# Altium schematic sheet AccelerometerSchematic.SchDoc(AccelerometerSchematic)
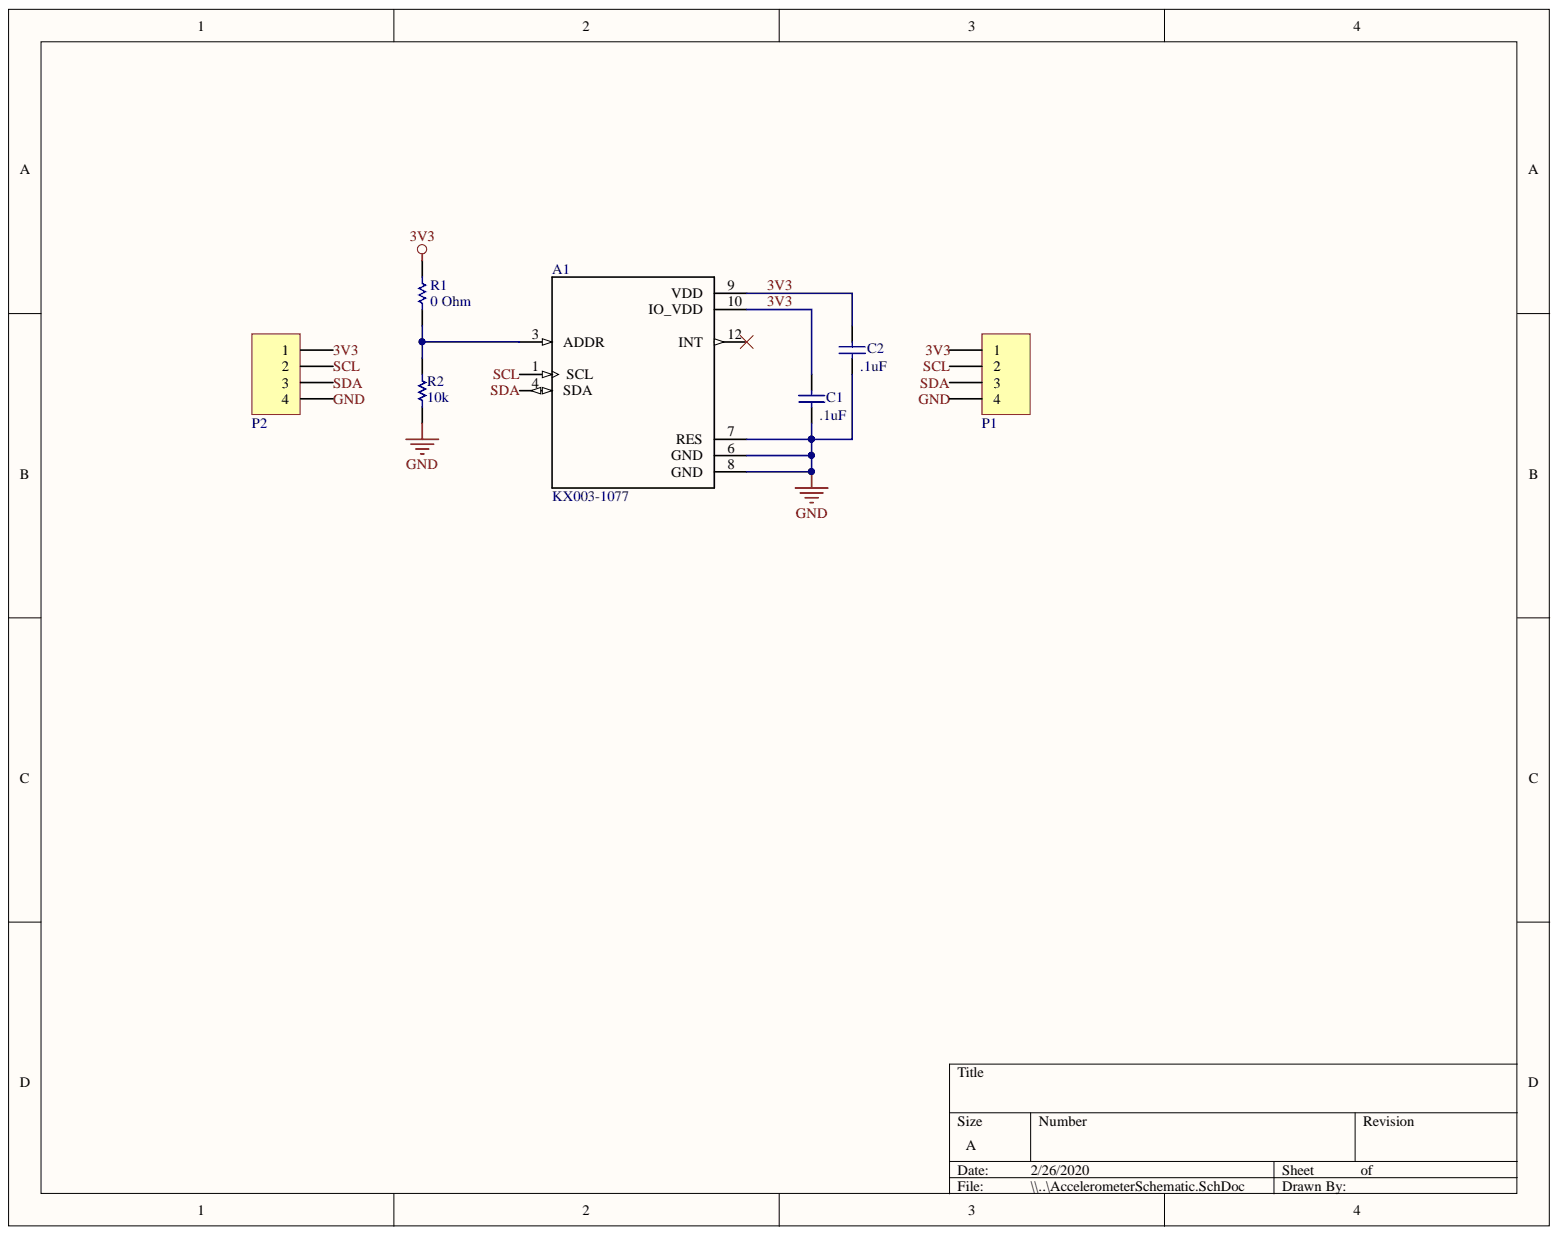
[diagram p0: AccelerometerSchematic.SchDoc]

## Parts
A1  KX003-1077  [*XDCR_KX003-1077]
C1  .1uF  [0805]
C2  .1uF  [0805]
P1  90120-0124  [HDR1X4]  (value not drawn)
P2  90120-0124  [HDR1X4]  (value not drawn)
R1  0 Ohm  [0805_RES_HD]  (no BOM)
R2  10k  [0805_RES_HD]

## Nets
3V3: A1.9(VDD) A1.10(IO_VDD) C1.2 C2.2 P1.1 P2.1 R1.2
GND: A1.6(GND) A1.7(RES) A1.8(GND) C1.1 C2.1 P1.4 P2.4 R2.1
SCL: A1.1(SCL) P1.2 P2.2
SDA: A1.4(SDA) P1.3 P2.3
NetA1_3: A1.3(ADDR) R1.1 R2.2

Not connected: A1 12(INT)

## Library data of the parts
A1 = KX003-1077: Accelerometer X, Y, Z Axis Â±2g, 4g, 8g, 16g 3.5kHz _X,Y_, 1.8kHz _Z_ 12-LGA _2x2_; MF: Rohm Semiconductor; SnapEDA_Link: https://www.snapeda.com/parts/KX003-1077/Rohm/view-part/3603894/?ref=snap; Package: VFLGA-12 Rohm Semiconductor; MP: KX003-1077; Library Name: KX003-1077.SchLib; Digi-Key_Purchase_URL: https://www.digikey.com/product-detail/en/rohm-semiconductor/KX003-1077/KX003-1077CT-ND/9679234?utm_source=snapeda&utm_medium=aggregator&utm_campaign=symbol; Digi-Key_Part_Number: KX003-1077CT-ND; Component Kind: Standard
C1 C2 = CL21A475KPFNNNE: .1, 10%, 50V; Manufacturer 1: Samsung; Database Table Name: capacitors; Supplier Part Number 1: 1276-1259-1-ND; Supplier 1: Digi-Key; Part Type: SMT; PackageReference: 0805; Manufacturer Part Number 1: CL21A475KPFNNNE; GFUPartNo: CL21A475KPFNNNE; Component Kind: Standard; Library Name: AltiumGFU64.SVNDbLib
P1 P2 = 90120-0124: CONN HEADER 4POS .100" STR TIN; Manufacturer 1: Molex; Database Table Name: connectors; Supplier Part Number 1: WM8074-ND; Supplier 1: Digi-Key; Part Type: THRU; Manufacturer Part Number 1: 90120-0124; GFUPartNo: 90120-0124; Component Kind: Standard; Library Name: AltiumGFU64.SVNDbLib
R1 = R-NP 0805: NO PLACE RESISTOR; Database Table Name: resistors; Simulation: RESISTOR; Signal Integrity: Res; GFUPartNo: R-NP 0805; Library Name: AltiumGFU64.SVNDbLib
R2 = RC2012F752CS: RES 10K OHM 1/8W 5% 0805; Manufacturer 1: Samsung; Database Table Name: resistors; Supplier Part Number 1: 1276-5324-1-ND; Supplier 1: Digi-Key; Simulation: RESISTOR; Signal Integrity: Res; Part Type: SMT; Manufacturer Part Number 1: RC2012F752CS; GFUPartNo: RC2012F752CS; Component Kind: Standard; Library Name: AltiumGFU64.SVNDbLib
